# Altium schematic sheet IMA_Ctrl.SchDoc
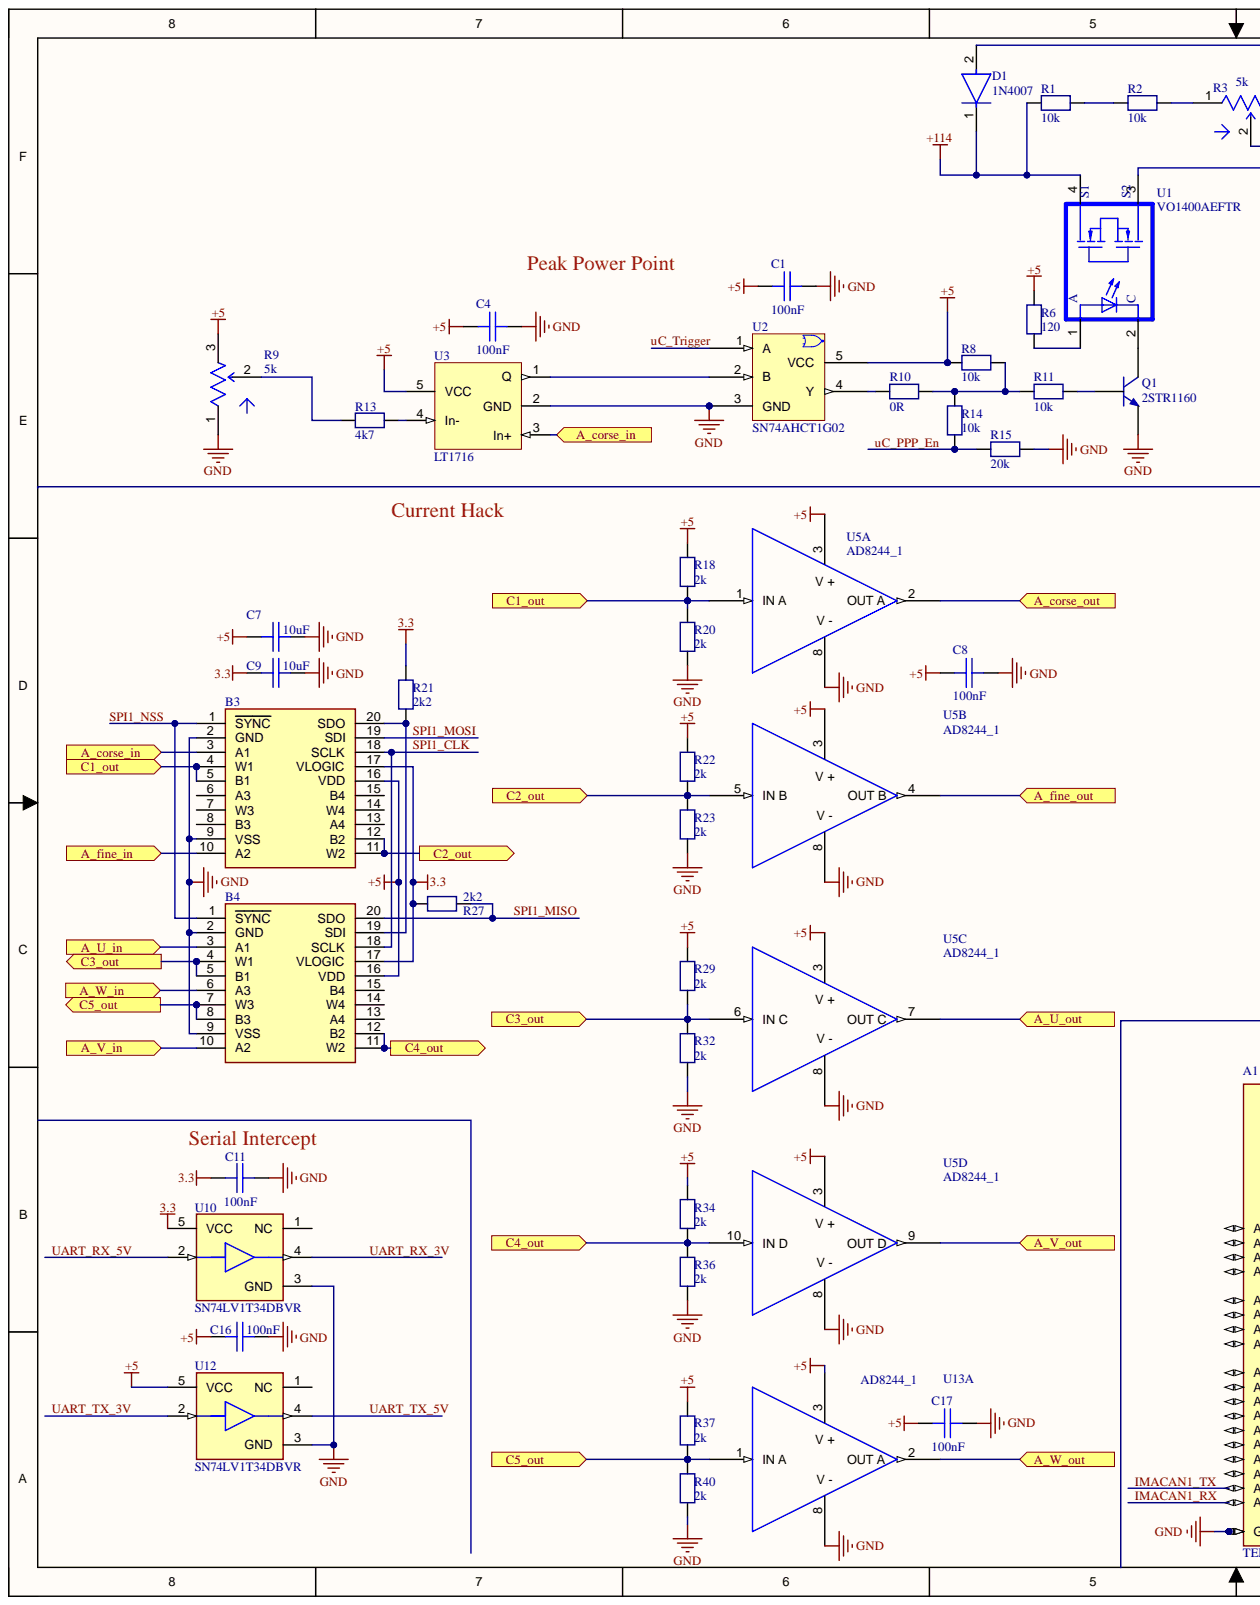
[diagram p0: IMA_Ctrl.SchDoc, left]
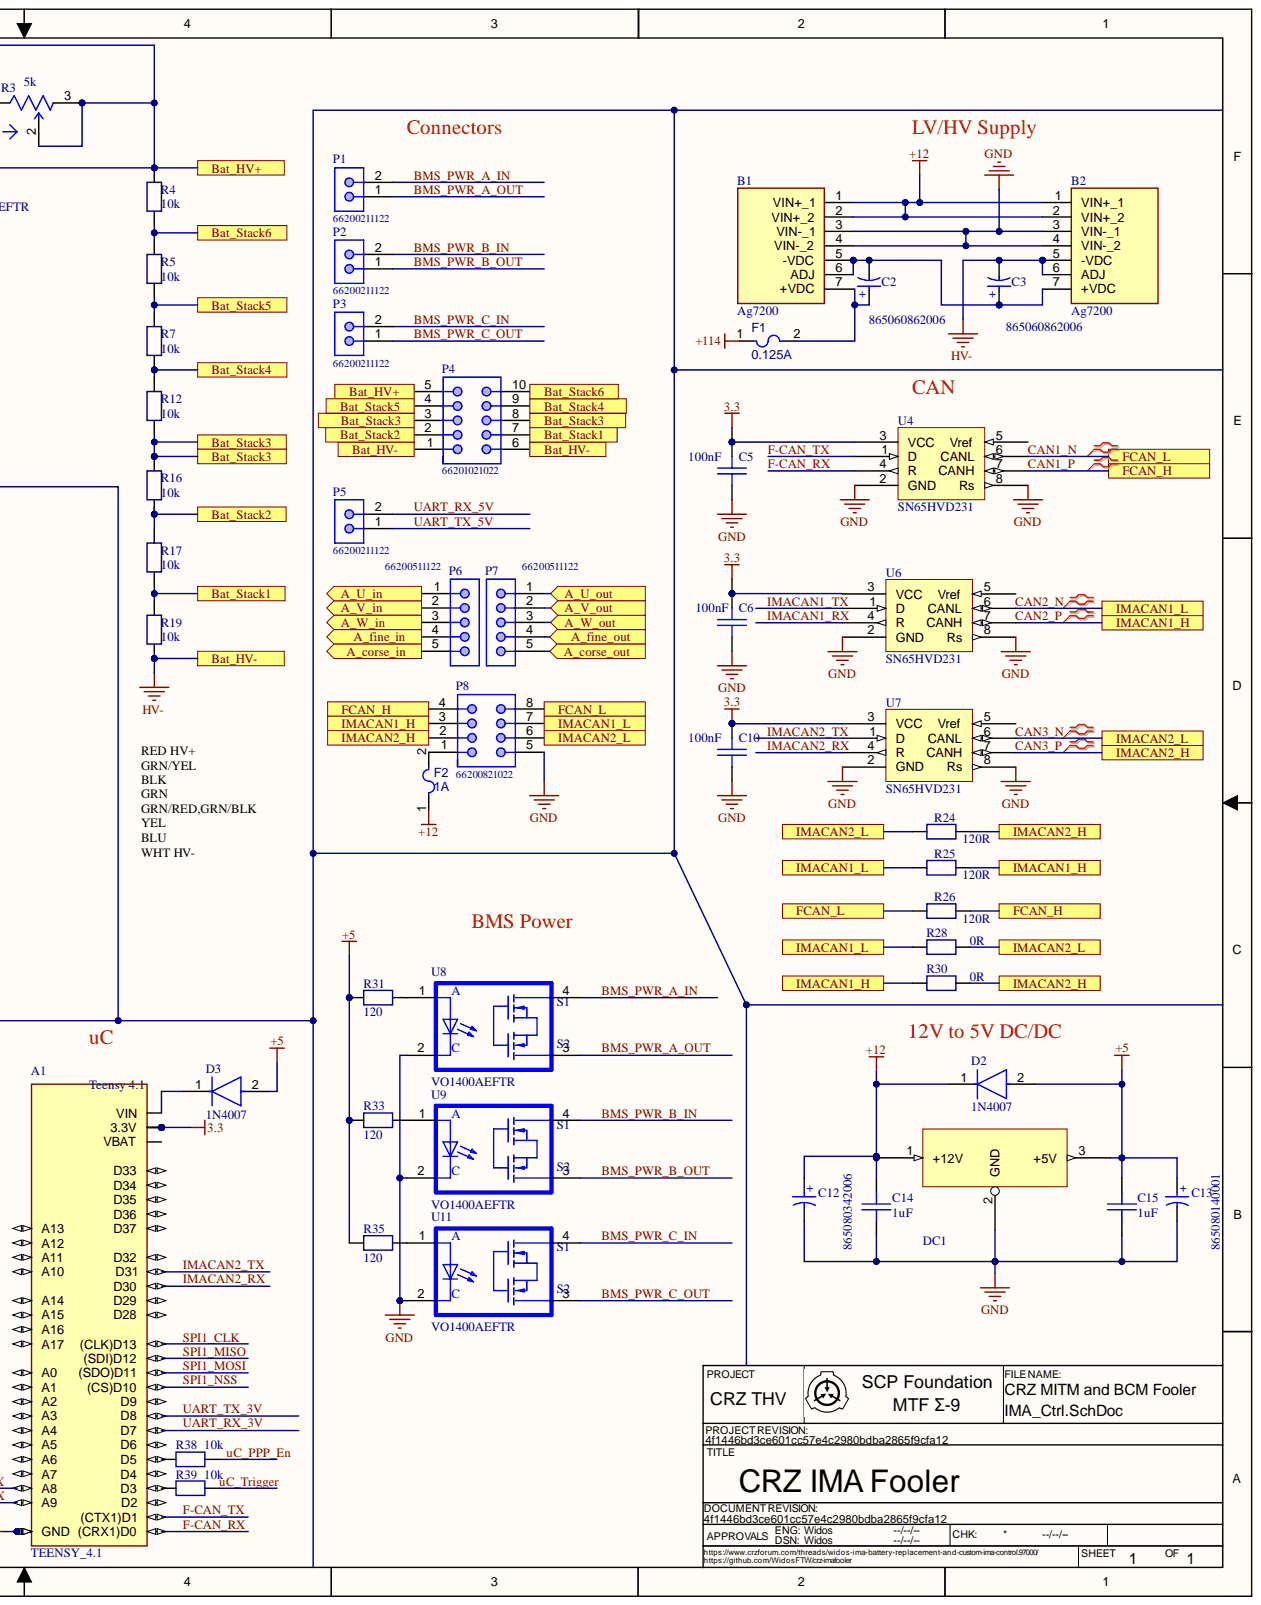
[diagram p1: IMA_Ctrl.SchDoc, right]

## Parts
A1  TEENSY_4.1  MP: Teensy 4.1  [TEENSY_LONG_EXT]
B1  Ag7200  [Ag7200]
B2  Ag7200  [Ag7200]
B3  AD5144BRUZ10  [SOP65P640X120-20N]  (value not drawn)
B4  AD5144BRUZ10  [SOP65P640X120-20N]  (value not drawn)
C1  Capacity: 100nF  [0805_Cap]  (hidden comment: 0805_Cap)
C2  865060862006  [WCAP-ASLL_D12.5H14]
C3  865060862006  [WCAP-ASLL_D12.5H14]
C4  Capacity: 100nF  [0805_Cap]  (hidden comment: 0805_Cap)
C5  Capacity: 100nF  [0805_Cap]  (hidden comment: 0805_Cap)
C6  Capacity: 100nF  [0805_Cap]  (hidden comment: 0805_Cap)
C7  Capacity: 10uF  [0805_Cap]  (hidden comment: 0805_Cap)
C8  Capacity: 100nF  [0805_Cap]  (hidden comment: 0805_Cap)
C9  Capacity: 10uF  [0805_Cap]  (hidden comment: 0805_Cap)
C10  Capacity: 100nF  [0805_Cap]  (hidden comment: 0805_Cap)
C11  Capacity: 100nF  [0805_Cap]  (hidden comment: 0805_Cap)
C12  865080342006  [WCAP-ASLI_D5H5.5]
C13  865080140001  [WCAP-ASLI_D4H5.5]
C14  Capacity: 1uF  [0805_Cap]  (hidden comment: 0805_Cap)
C15  Capacity: 1uF  [0805_Cap]  (hidden comment: 0805_Cap)
C16  Capacity: 100nF  [0805_Cap]  (hidden comment: 0805_Cap)
C17  Capacity: 100nF  [0805_Cap]  (hidden comment: 0805_Cap)
D1  1N4007  [FP-1N4007-MFG]
D2  1N4007  [FP-1N4007-MFG]
D3  1N4007  [FP-1N4007-MFG]
DC1  RECOM-785-5.0  [RECOM_DCDC]  (value not drawn)
F1  0.125A  [LFUS-466_V]
F2  1A  [LFUS-466_V]
P1  66200211122  [66200211122]
P2  66200211122  [66200211122]
P3  66200211122  [66200211122]
P4  66201021022  [66201021022]
P5  66200211122  [66200211122]
P6  66200511122  [66200511122]
P7  66200511122  [66200511122]
P8  66200821022  [66200821022]
Q1  2STR1160  [SOT85P255X140-3N]
R1  Resistance: 10k  [0805_Res]  (hidden comment: 0805_Resistor)
R2  Resistance: 10k  [0805_Res]  (hidden comment: 0805_Resistor)
R3  5k  [FP-PV36W-MFG]
R4  Resistance: 10k  [0805_Res]  (hidden comment: 0805_Resistor)
R5  Resistance: 10k  [0805_Res]  (hidden comment: 0805_Resistor)
R6  0805_Resistor  [0805_Res]  (value not drawn)
R7  Resistance: 10k  [0805_Res]  (hidden comment: 0805_Resistor)
R8  Resistance: 10k  [0805_Res]  (hidden comment: 0805_Resistor)
R9  5k  [FP-PV36W-MFG]
R10  Resistance: 0R  [0805_Res]  (hidden comment: 0805_Resistor)
R11  Resistance: 10k  [0805_Res]  (hidden comment: 0805_Resistor)
R12  Resistance: 10k  [0805_Res]  (hidden comment: 0805_Resistor)
R13  Resistance: 4k7  [0805_Res]  (hidden comment: 0805_Resistor)
R14  Resistance: 10k  [0805_Res]  (hidden comment: 0805_Resistor)
R15  Resistance: 20k  [0805_Res]  (hidden comment: 0805_Resistor)
R16  Resistance: 10k  [0805_Res]  (hidden comment: 0805_Resistor)
R17  Resistance: 10k  [0805_Res]  (hidden comment: 0805_Resistor)
R18  Resistance: 2k  [0805_Res]  (hidden comment: 0805_Resistor)
R19  Resistance: 10k  [0805_Res]  (hidden comment: 0805_Resistor)
R20  Resistance: 2k  [0805_Res]  (hidden comment: 0805_Resistor)
R21  Resistance: 2k2  [0805_Res]  (hidden comment: 0805_Resistor)
R22  Resistance: 2k  [0805_Res]  (hidden comment: 0805_Resistor)
R23  Resistance: 2k  [0805_Res]  (hidden comment: 0805_Resistor)
R24  Resistance: 120R  [0805_Res]  (hidden comment: 0805_Resistor)
R25  Resistance: 120R  [0805_Res]  (hidden comment: 0805_Resistor)
R26  Resistance: 120R  [0805_Res]  (hidden comment: 0805_Resistor)
R27  Resistance: 2k2  [0805_Res]  (hidden comment: 0805_Resistor)
R28  Resistance: 0R  [0805_Res]  (hidden comment: 0805_Resistor)
R29  Resistance: 2k  [0805_Res]  (hidden comment: 0805_Resistor)
R30  Resistance: 0R  [0805_Res]  (hidden comment: 0805_Resistor)
R31  0805_Resistor  [0805_Res]  (value not drawn)
R32  Resistance: 2k  [0805_Res]  (hidden comment: 0805_Resistor)
R33  0805_Resistor  [0805_Res]  (value not drawn)
R34  Resistance: 2k  [0805_Res]  (hidden comment: 0805_Resistor)
R35  0805_Resistor  [0805_Res]  (value not drawn)
R36  Resistance: 2k  [0805_Res]  (hidden comment: 0805_Resistor)
R37  Resistance: 2k  [0805_Res]  (hidden comment: 0805_Resistor)
R38  Resistance: 10k  [0805_Res]  (hidden comment: 0805_Resistor)
R39  Resistance: 10k  [0805_Res]  (hidden comment: 0805_Resistor)
R40  Resistance: 2k  [0805_Res]  (hidden comment: 0805_Resistor)
U1  VO1400AEFTR  [SOIC254P695X242-4N]
U2  SN74AHCT1G02  [SOT23-5_LT1716]
U3  LT1716  [SOT23-5_LT1716]
U4  SN65HVD231  [D008_N]
U5  AD8244_1  [AD8244]  (parts A,B,C,D)
U6  SN65HVD231  [D008_N]
U7  SN65HVD231  [D008_N]
U8  VO1400AEFTR  [SOIC254P695X242-4N]
U9  VO1400AEFTR  [SOIC254P695X242-4N]
U10  SN74LV1T34DBVR  [FP-DBV0005A-IPC_B]
U11  VO1400AEFTR  [SOIC254P695X242-4N]
U12  SN74LV1T34DBVR  [FP-DBV0005A-IPC_B]
U13  AD8244_1  [AD8244]  (part A)

## Ports
A_corse_in, A_corse_out, A_fine_in, A_fine_out, A_U_in, A_U_out, A_V_in, A_V_out, A_W_in, A_W_out, Bat_HV+, Bat_HV-, Bat_Stack1, Bat_Stack2, Bat_Stack3, Bat_Stack4, Bat_Stack5, Bat_Stack6, C1_out, C2_out, C3_out, C4_out, C5_out, FCAN_H, FCAN_L, IMACAN1_H, IMACAN1_L, IMACAN2_H, IMACAN2_L

## Nets
3.3: A1.3V3(3.3V) A1.3V3@2 A1.3V3@3 B3.17(VLOGIC) B4.17(VLOGIC) C5.2 C6.2 C9.1 C10.2 C11.1 R21.1 R27.2 U4.3(VCC) U6.3(VCC) U7.3(VCC) U10.5(VCC)
+5: B3.16(VDD) B4.16(VDD) C1.1 C4.1 C7.1 C8.1 C13.1 C15.2 C16.1 C17.1 D2.2 D3.2 DC1.3 R6.2 R8.1 R9.3 R18.1 R22.1 R29.1 R31.1 R33.1 R34.1 R35.1 R37.1 U2.5(VCC) U3.5(VCC) U5.3(V) U12.5(VCC) U13.3(V)
+12: B1.1(VIN+_1) B1.2(VIN+_2) B2.1(VIN+_1) B2.2(VIN+_2) C12.1 C14.2 D2.1 DC1.1 F2.1
+114: D1.1 F1.1 R1.1 U1.4
GND: A1.AGND/GND A1.GND A1.GND@2 B1.3(VIN-_1) B1.4(VIN-_2) B2.3(VIN-_1) B2.4(VIN-_2) B3.2(GND) B3.9(VSS) B4.2(GND) B4.9(VSS) C1.2 C4.2 C5.1 C6.1 C7.2 C8.2 C9.2 C10.1 C11.2 C12.2 C13.2 C14.1 C15.1 C16.2 C17.2 DC1.2 P8.5 Q1.2 R9.1 R15.2 R20.2 R23.2 R32.2 R36.2 R40.2 U2.3(GND) U3.2(GND) U4.2(GND) U4.8(Rs) U5.8(V) U6.2(GND) U6.8(Rs) U7.2(GND) U7.8(Rs) U8.2 U9.2 U10.3(GND) U11.2(C) U12.3(GND) U13.8(V)
HV-: B2.5(-VDC) B2.6(ADJ) C3.2 P4.1 P4.6 R19.2
A_corse_in: B3.3(A1) P6.5 U3.3(In+) ; port A_corse_in
A_corse_out: P7.5 U5.2(OUT_A) ; port A_corse_out
A_fine_in: B3.10(A2) P6.4 ; port A_fine_in
A_fine_out: P7.4 U5.4(OUT_B) ; port A_fine_out
A_U_in: B4.3(A1) P6.1 ; port A_U_in
A_U_out: P7.1 U5.7(OUT_C) ; port A_U_out
A_V_in: B4.10(A2) P6.2 ; port A_V_in
A_V_out: P7.2 U5.9(OUT_D) ; port A_V_out
A_W_in: B4.6(A3) P6.3 ; port A_W_in
A_W_out: P7.3 U13.2(OUT_A) ; port A_W_out
Bat_Stack1: P4.7 R17.2 R19.1 ; port Bat_Stack1
Bat_Stack2: P4.2 R16.2 R17.1 ; port Bat_Stack2
Bat_Stack3: P4.3 P4.8 R12.2 R16.1 ; port Bat_Stack3
Bat_Stack4: P4.9 R7.2 R12.1 ; port Bat_Stack4
Bat_Stack5: P4.4 R5.2 ; port Bat_Stack5
Bat_Stack6: P4.10 R4.2 R5.1 ; port Bat_Stack6
BMS_PWR_A_IN: P1.2 U8.4
BMS_PWR_A_OUT: P1.1 U8.3
BMS_PWR_B_IN: P2.2 U9.4
BMS_PWR_B_OUT: P2.1 U9.3
BMS_PWR_C_IN: P3.2 U11.4
BMS_PWR_C_OUT: P3.1 U11.3
C1_out: B3.4(W1) B3.5(B1) R18.2 R20.1 U5.1(IN_A) ; port C1_out
C2_out: B3.11(W2) B3.12(B2) R22.2 R23.1 U5.5(IN_B) ; port C2_out
C3_out: B4.4(W1) B4.5(B1) R29.2 R32.1 U5.6(IN_C) ; port C3_out
C4_out: B4.11(W2) B4.12(B2) R34.2 R36.1 U5.10(IN_D) ; port C4_out
C5_out: B4.7(W3) B4.8(B3) R37.2 R40.1 U13.1(IN_A) ; port C5_out
CAN1_N: P8.8 R26.1 U4.6(CANL) ; port FCAN_L
CAN1_P: P8.4 R26.2 U4.7(CANH) ; port FCAN_H
CAN2_N: P8.7 R25.1 R28.1 U6.6(CANL) ; port IMACAN1_L
CAN2_P: P8.3 R25.2 R30.1 U6.7(CANH) ; port IMACAN1_H
CAN3_N: P8.6 R24.1 R28.2 U7.6(CANL) ; port IMACAN2_L
CAN3_P: P8.2 R24.2 R30.2 U7.7(CANH) ; port IMACAN2_H
F-CAN_RX: A1.D0((CRX1)D0) U4.4(R)
F-CAN_TX: A1.D1((CTX1)D1) U4.1(D)
IMACAN1_RX: A1.D23(A9) U6.4(R)
IMACAN1_TX: A1.D22(A8) U6.1(D)
IMACAN2_RX: A1.D30 U7.4(R)
IMACAN2_TX: A1.D31 U7.1(D)
SPI1_CLK: A1.D13((CLK)D13) B3.18(SCLK) B4.18(SCLK)
SPI1_MISO: A1.D12((SDI)D12) B4.20(SDO) R27.1
SPI1_MOSI: A1.D11((SDO)D11) B3.19(SDI)
SPI1_NSS: A1.D10((CS)D10) B3.1(SYNC) B4.1(SYNC)
UART_RX_3V: A1.D7 U10.4
UART_RX_5V: P5.2 U10.2
UART_TX_3V: A1.D8 U12.2
UART_TX_5V: P5.1 U12.4
uC_PPP_En: R14.1 R15.1 R38.2
uC_Trigger: R39.2 U2.1(A)
NetA1_D3: A1.D3 R39.1
NetA1_D5: A1.D5 R38.1
NetA1_VIN: A1.VIN D3.1
NetB1_5: B1.5(-VDC) B1.6(ADJ) B2.7(+VDC) C2.2 C3.1
NetB1_7: B1.7(+VDC) C2.1 F1.2
NetB3_20: B3.20(SDO) B4.19(SDI) R21.2
NetD1_2: D1.2 P4.5 R3.2 R3.3 R4.1 U1.3
NetF2_2: F2.2 P8.1
NetQ1_1: Q1.1 R11.2
NetQ1_3: Q1.3 U1.2(C)
NetR1_2: R1.2 R2.1
NetR2_2: R2.2 R3.1
NetR6_1: R6.1 U1.1(A)
NetR8_2: R8.2 R10.2 R11.1 R14.2
NetR9_2: R9.2 R13.1
NetR10_1: R10.1 U2.4(Y)
NetR13_2: R13.2 U3.4(In-)
NetR31_2: R31.2 U8.1
NetR33_2: R33.2 U9.1
NetR35_2: R35.2 U11.1
NetU2_2: U2.2(B) U3.1(Q)

Not connected: A1 D2 D4 D6 D9 D14(A0) D15 D16(A2) D17(A3) D18(A4) D19(A5) D20(A6) D21(A7) D24(A10) D25(A11) D26(A12) D27(A13) D28 D29 D32 D33 D34 D35 D36 D37 D38(A14) D39(A15) D40(A16) D41(A17) VBAT; B3 6(A3) 7(W3) 8 13(A4) 14(W4) 15(B4); B4 13(A4) 14(W4) 15; R7 1; U4 5(Vref); U6 5(Vref); U7 5(Vref); U10 1(NC); U12 1(NC)

## Library data of the parts
A1 = CMP-033-000000-1: Teensy 4.1 with VBAT <br> <a href="https://www.pjrc.com/store/teensy41.html#pins">Datasheet</a> <br> Requires ordering of: <ul> <li>2: 24 pin, 0.1" pitch female headers</li> <li>1:  2 pin, 0.1" pitch female headers</li> </ul>; MF: PJRC; Check_prices: https://www.snapeda.com/parts/Teensy%204.1/PJRC/view-part/?ref=eda; Component Kind: Standard; Component Type: Standard; Design Item ID: TEENSY_4.1; Package: None; Pin Count: 50; SnapEDA_Link: https://www.snapeda.com/parts/Teensy%204.1/PJRC/view-part/?ref=snap; Source: TEENSY_4.1.SchLib; Library Name: Racetech Racing Team TU Bergakademie Freiberg e.V.
B1 B2 = CMP-029-000000-1: Isolated DC/DC Converters 20W boost converter. 36V-57Vout, 5V-15V in, SIL; Manufacturer_Name: Silvertel; Geometry.Height: 14mm; Component Kind: Standard; Datasheet Link: https://silvertel.com/images/datasheets/Ag7200-datasheet-20W-Isolated-57V-DC-DC-Boost-Converter-Module.pdf; Design Item ID: Ag7200; Manufacturer_Part_Number: Ag7200; Mouser Part Number: 480-AG7200; Mouser Price/Stock: https://www.mouser.co.uk/ProductDetail/Silvertel/Ag7200?qs=OlC7AqGiEDkYk2DJmVBe2Q%3D%3D; Source: Ag7200.SchLib; Library Name: Racetech Racing Team TU Bergakademie Freiberg e.V.
B3 B4 = CMP-036-000000-1: AD5144BRUZ10, Digital Potentiometer 10k 256-Position Linear 4-channel Serial-SPI 20-Pin TSSOP; Manufacturer_Name: Analog Devices; Geometry.Height: 1.2mm; Arrow Part Number: AD5144BRUZ10; Arrow Price/Stock: https://www.arrow.com/en/products/ad5144bruz10/analog-devices?region=nac; Component Kind: Standard; Datasheet Link: http://uk.rs-online.com/web/p/products/7968827; Design Item ID: AD5144BRUZ10; Manufacturer_Part_Number: AD5144BRUZ10; Mouser Part Number: 584-AD5144BRUZ10; Mouser Price/Stock: https://www.mouser.co.uk/ProductDetail/Analog-Devices/AD5144BRUZ10?qs=NmRFExCfTkGSml8dn6R%2FyA%3D%3D; Source: AD5144BRUZ10.SchLib; Library Name: Racetech Racing Team TU Bergakademie Freiberg e.V.
C1 C4-C11 C14-C17 = CMP-004-000000-1: Component Kind: Standard; Component Type: Standard; Design Item ID: 0805_Cap; Pin Count: 2; Source: KEMET.SchLib; Library Name: Racetech Racing Team TU Bergakademie Freiberg e.V.
C2 C3 = CMP-03913-130590180-1: 865060862006 Cap, 68Uf, 20%, 100V, Alu Elec Smd Rohs Compliant: Yes; Capacitance: 68µF; Impedance: 700mΩ; Insulation Resistance: 1.47059MΩ; Leakage Current: 68µA; Ripple Current: 600mA; Component Kind: Standard; Diameter: 12.5mm; Length: 14mm; Library Name: Altium Content Vault; NexarPartId: 130590180; Voltage Rating: 100V; Width: 13.5mm
C12 = CMP-03913-53914570-1: 865080342006 WCAP-ASLI Aluminum Electrolytic Capacitors; Capacitance: 47µF; ESR (Equivalent Series Resistance): 832.685mΩ; Impedance: 1.05Ω; Insulation Resistance: 2.12766MΩ; Leakage Current: 7.52µA; Life (Hours): 2000hours; Polarity: Polar; Ripple Current: 195mA; Tolerance: 20%; Voltage Rating: 16V; Case/Package: Radial; Component Kind: Standard; Diameter: 5mm; Height: 5.5mm; Height - Seated (Max): 5.5118mm; Length: 5.5mm; Library Name: Altium Content Vault; Max Operating Temperature: 105°C; Min Operating Temperature: -55°C; Mount: Surface Mount; NexarPartId: 53914570; Packaging: Tape & Reel (TR); REACH SVHC: Unknown; Ripple Current (AC): 195mA; RoHS: Compliant; Voltage Rating (DC): 16V; Width: 5.3mm
C13 = CMP-03913-53914532-1: 865080140001 WCAP-ASLI Aluminum Electrolytic Capacitors; Capacitance: 22µF; ESR (Equivalent Series Resistance): 1.72087Ω; Impedance: 2.2Ω; Insulation Resistance: 2.1MΩ; Leakage Current: 3µA; Life (Hours): 2000hours; Polarity: Polar; Ripple Current: 75mA; Tolerance: 20%; Voltage Rating: 6.3V; Case/Package: Radial; Component Kind: Standard; Diameter: 4mm; Height: 5.5mm; Height - Seated (Max): 5.5118mm; Length: 5.5mm; Library Name: Altium Content Vault; Max Operating Temperature: 105°C; Min Operating Temperature: -55°C; Mount: Surface Mount; NexarPartId: 53914532; Packaging: Tape & Reel (TR); REACH SVHC: Unknown; Ripple Current (AC): 75mA; RoHS: Compliant; Voltage Rating (DC): 6.3V; Width: 4.3mm
D1-D3 = CMP-123542-000001-1: Rectifier diode 1000 V 1 A DO-41, ,; Manufacturer: Diotec; Capacitance: 15pF; Forward Current: 1A; Max Junction Temp: +175°C; Max Repetitive Reverse Voltage: 1000V; Max Surge Forward Current: 27A; Peak Reverse Current: 5uA; Value: 15pF; Automotive: No; Category: Diode; Component Kind: Standard; ComponentLink2Description: Datasheet; ComponentLink2URL: https://datasheet.octopart.com/1N4007-Diotec-datasheet-62346875.pdf; Device Class L1: Discrete Semiconductors; Device Class L2: Diodes; Device Class L3: Rectifier Diodes; Height: 2.8mm; Ipc Land Pattern Name: DIOAD888W100L520D280; Lead Free: Yes; Library Name: Altium Content Vault; Manufacturer Part Number: 1N4007; Octopart: https://octopart.com/1n4007-diotec-4040411; Package: 1N4007; Reverse Voltage: 1000V; RoHS: TRUE; Temperature: -50°C to +175°C; Temperature Range High: +175°C; Temperature Range Low: -50°C
DC1 = CMP-007-000012-1: RECOM 785-5.0 DC/DC; Component Kind: Standard; Component Type: Standard; Design Item ID: RECOM-785-5.0; Pin Count: 3; Source: RECOM_785-5.0.SchLib; Library Name: Racetech Racing Team TU Bergakademie Freiberg e.V.
F1 F2 = CMP-005-00010-2: Surface Mount Fuses 7A 24V VFA SLIMLINE; Component Kind: Standard; Current Rating: 7A; Pins: 2; Library Name: Racetech Racing Team TU Bergakademie Freiberg e.V.
P1-P3 P5 = CMP-020-000001-1: THT Male Header WR-MPC3, Vertical, Single Row, pitch 3 mm, 2 pins; Contact Resistance: 10 mOhm; Pin Number: 2; Pitch: 3 mm; Working Voltage: 250 VAC; Component Kind: Standard; Component Type: Standard; ComponentLink1Description: Manufacturer; ComponentLink1URL: http://www.we-online.de; ComponentLink2Description: Datasheet; ComponentLink2URL: https://katalog.we-online.de/em/datasheet/6620xx11122.pdf; Design Item ID: 66200211122; Gender: Male; Mounting Technology: THT; Operating Temperature: -40 to 105 degC; Orientation: Vertical; Packaging: Tube; Part Number: 66200211122; Pin Count: 2; Published Date: September 2018; Source: Male Headers WR-MPC3.SchLib; Library Name: Racetech Racing Team TU Bergakademie Freiberg e.V.; Publisher: Wurth Elektronik
P4 = CMP-020-000046-1: THT Male Header WR-MPC3, with Snap-in Plastic Pegs, Right Angled, Dual Row, pitch 3 mm, 10 pins; Contact Resistance: 10 mOhm; Pin Number: 10; Pitch: 3 mm; Working Voltage: 250 VAC; Component Kind: Standard; Component Type: Standard; ComponentLink1Description: Manufacturer; ComponentLink1URL: http://www.we-online.de; ComponentLink2Description: Datasheet; ComponentLink2URL: https://katalog.we-online.de/em/datasheet/6620xx21022.pdf; Design Item ID: 66201021022; Gender: Male; Mounting Technology: THT; Operating Temperature: -40 to 105 degC; Orientation: Right Angled; Packaging: Tube; Part Number: 66201021022; Pin Count: 10; Published Date: September 2018; Source: Male Headers WR-MPC3.SchLib; Library Name: Racetech Racing Team TU Bergakademie Freiberg e.V.; Publisher: Wurth Elektronik
P6 P7 = CMP-020-000021-1: THT Male Header WR-MPC3, Vertical, Single Row, pitch 3 mm, 5 pins; Contact Resistance: 10 mOhm; Pin Number: 5; Pitch: 3 mm; Working Voltage: 250 VAC; Component Kind: Standard; Component Type: Standard; ComponentLink1Description: Manufacturer; ComponentLink1URL: http://www.we-online.de; ComponentLink2Description: Datasheet; ComponentLink2URL: https://katalog.we-online.de/em/datasheet/6620xx11122.pdf; Design Item ID: 66200511122; Gender: Male; Mounting Technology: THT; Operating Temperature: -40 to 105 degC; Orientation: Vertical; Packaging: Tube; Part Number: 66200511122; Pin Count: 5; Published Date: September 2018; Source: Male Headers WR-MPC3.SchLib; Library Name: Racetech Racing Team TU Bergakademie Freiberg e.V.; Publisher: Wurth Elektronik
P8 = CMP-020-000035-1: THT Male Header WR-MPC3, with Snap-in Plastic Pegs, Right Angled, Dual Row, pitch 3 mm, 8 pins; Contact Resistance: 10 mOhm; Pin Number: 8; Pitch: 3 mm; Working Voltage: 250 VAC; Component Kind: Standard; Component Type: Standard; ComponentLink1Description: Manufacturer; ComponentLink1URL: http://www.we-online.de; ComponentLink2Description: Datasheet; ComponentLink2URL: https://katalog.we-online.de/em/datasheet/6620xx21022.pdf; Design Item ID: 66200821022; Gender: Male; Mounting Technology: THT; Operating Temperature: -40 to 105 degC; Orientation: Right Angled; Packaging: Tube; Part Number: 66200821022; Pin Count: 8; Published Date: September 2018; Source: Male Headers WR-MPC3.SchLib; Library Name: Racetech Racing Team TU Bergakademie Freiberg e.V.; Publisher: Wurth Elektronik
Q1 = CMP-018-000000-1: Low voltage fast-switching NPN power transistor; Component Kind: Standard; Component Type: Standard; Design Item ID: 2STR1160; Pin Count: 3; Source: 2STRx160.SchLib; Library Name: Racetech Racing Team TU Bergakademie Freiberg e.V.
R1 R2 R4 R5 R7 R8 R10-R30 R32 R34 R36-R40 = CMP-015-000000-1: Component Kind: Standard; Component Type: Standard; Design Item ID: 0805_Resistor; Pin Count: 2; Source: Resistors.SchLib; Library Name: Racetech Racing Team TU Bergakademie Freiberg e.V.
R3 R9 = CMP-07234-000009-1: TRIMMER 1K OHM 0.5W PC PIN TOP; Manufacturer: Bourns; Manufacturer Part Number: PV36W102C01B00; Resistance: 1kΩ; Standoff Height: 0.38mm; Temperature Coefficient: 100ppm/°C; Tolerance: 10%; Value: 1kΩ; Automotive: No; Category: Res; Component Kind: Standard; ComponentLink2Description: Datasheet; ComponentLink2URL: https://www.bourns.com/docs/Product-Datasheets/pv36.pdf; Composition: Cermet; Device Class L1: Passive Components; Device Class L2: Resistors; Device Class L3: Variable Resistors and Potentiometers; Digikey Description: TRIMMER 1K OHM 0.5W PC PIN TOP; Height: 12.05mm; Lead Free: Yes; Library Name: Altium Content Vault; Mouser Description: Trimmer Resistors - Through Hole 1.0Kohms 10mm Square 25turn; Octopart: https://octopart.com/pv36w102c01b00-bourns-71612321; Package: PV36W; Power Rating: 0.5W; RoHS: TRUE; Series: PV36; Temperature: -55°C to +125°C; Temperature Range High: +125°C; Temperature Range Low: -55°C
R6 R31 R33 R35 = CMP-015-000000-1: Resistance: 120; Component Kind: Standard; Component Type: Standard; Design Item ID: 0805_Resistor; Pin Count: 2; Source: Resistors.SchLib; Library Name: Racetech Racing Team TU Bergakademie Freiberg e.V.
U1 U8 U9 U11 = CMP-031-000000-1: Solid State SPST-NO _1 Form A_ 4-SOP _0.173, 4.40mm_; MF: Vishay; Component Kind: Standard; Component Type: Standard; Design Item ID: VO1400AEFTR; Digi-Key_Part_Number: VO1400AEFCT-ND; Digi-Key_Purchase_URL: https://www.digikey.com/product-detail/en/vishay-semiconductor-opto-division/VO1400AEFTR/VO1400AEFCT-ND/4743822?utm_source=snapeda&utm_medium=aggregator&utm_campaign=symbol; MP: VO1400AEFTR; Package: SOP-4 Vishay; Pin Count: 4; SnapEDA_Link: https://www.snapeda.com/parts/VO1400AEFTR/Vishay%20Semiconductor%20Opto%20Division/view-part/427521/?ref=snap; Source: SnapEDAsch.SchLib; Library Name: Racetech Racing Team TU Bergakademie Freiberg e.V.
U2 = CMP-018-000101-4: Single 2-Input Negative Gate; Component Kind: Standard; Component Type: Standard; Design Item ID: SN74AHCT1G02; Pin Count: 5; Source: SN74AHCT1G02.SchLib; Library Name: Racetech Racing Team TU Bergakademie Freiberg e.V.
U3 = CMP-011-000015-1: LT1716 Comparator +5V; Component Kind: Standard; Component Type: Standard; Design Item ID: LT1716; Pin Count: 5; Source: LT1716_Comparator.SchLib; Library Name: Racetech Racing Team TU Bergakademie Freiberg e.V.
U4 U6 U7 = SN65HVD231: 3.3-V CAN Transceiver; Component Kind: Standard; Library Name: SN65HVD231.SchLib
U5 U13 = CMP-018-000006-1: Precision FET Input Quad Buffer,   MSOP-10,   Single-Supply, Low Power; Component Kind: Standard; Component Type: Standard; ComponentLink1Description: Datasheet; ComponentLink1URL: https://www.analog.com/media/en/technical-documentation/data-sheets/AD8244.pdf; Design Item ID: AD8244_1; Pin Count: 16; Source: AD8244.SchLib; Library Name: Racetech Racing Team TU Bergakademie Freiberg e.V.
U10 U12 = CMP-0859-00123-4: IC BUF NON-INVERT 5.5V SOT23-5; High Level Output Current: -8mA; Logic Function: Buffer; Low Level Output Current: 8mA; Min Supply Voltage: 1.6V; Number of Bits: 1; Polarity: Non-Inverting; Propagation Delay: 15.5ns; Quiescent Current: 1uA; Case/Package: SOT-23-5; Component Kind: Standard; Lead Free: Lead Free; Library Name: Altium Content Vault; Max Operating Temperature: 125°C; Max Supply Voltage: 5.5V; Min Operating Temperature: -40°C; Mount: Surface Mount; Number of Bits per Element: 1; Number of Channels: 1; Number of Elements: 1; Number of Input Lines: 1; Number of Inputs: 1; Number of Output Lines: 1; Number of Outputs: 1; Number of Pins: 5; Operating Supply Current: 8mA; Package Quantity: 3000; Packaging: Tape and Reel; Radiation Hardening: No; REACH SVHC: No SVHC; Weight: 0.000353oz
